# Altium schematic sheet stlink.SchDoc
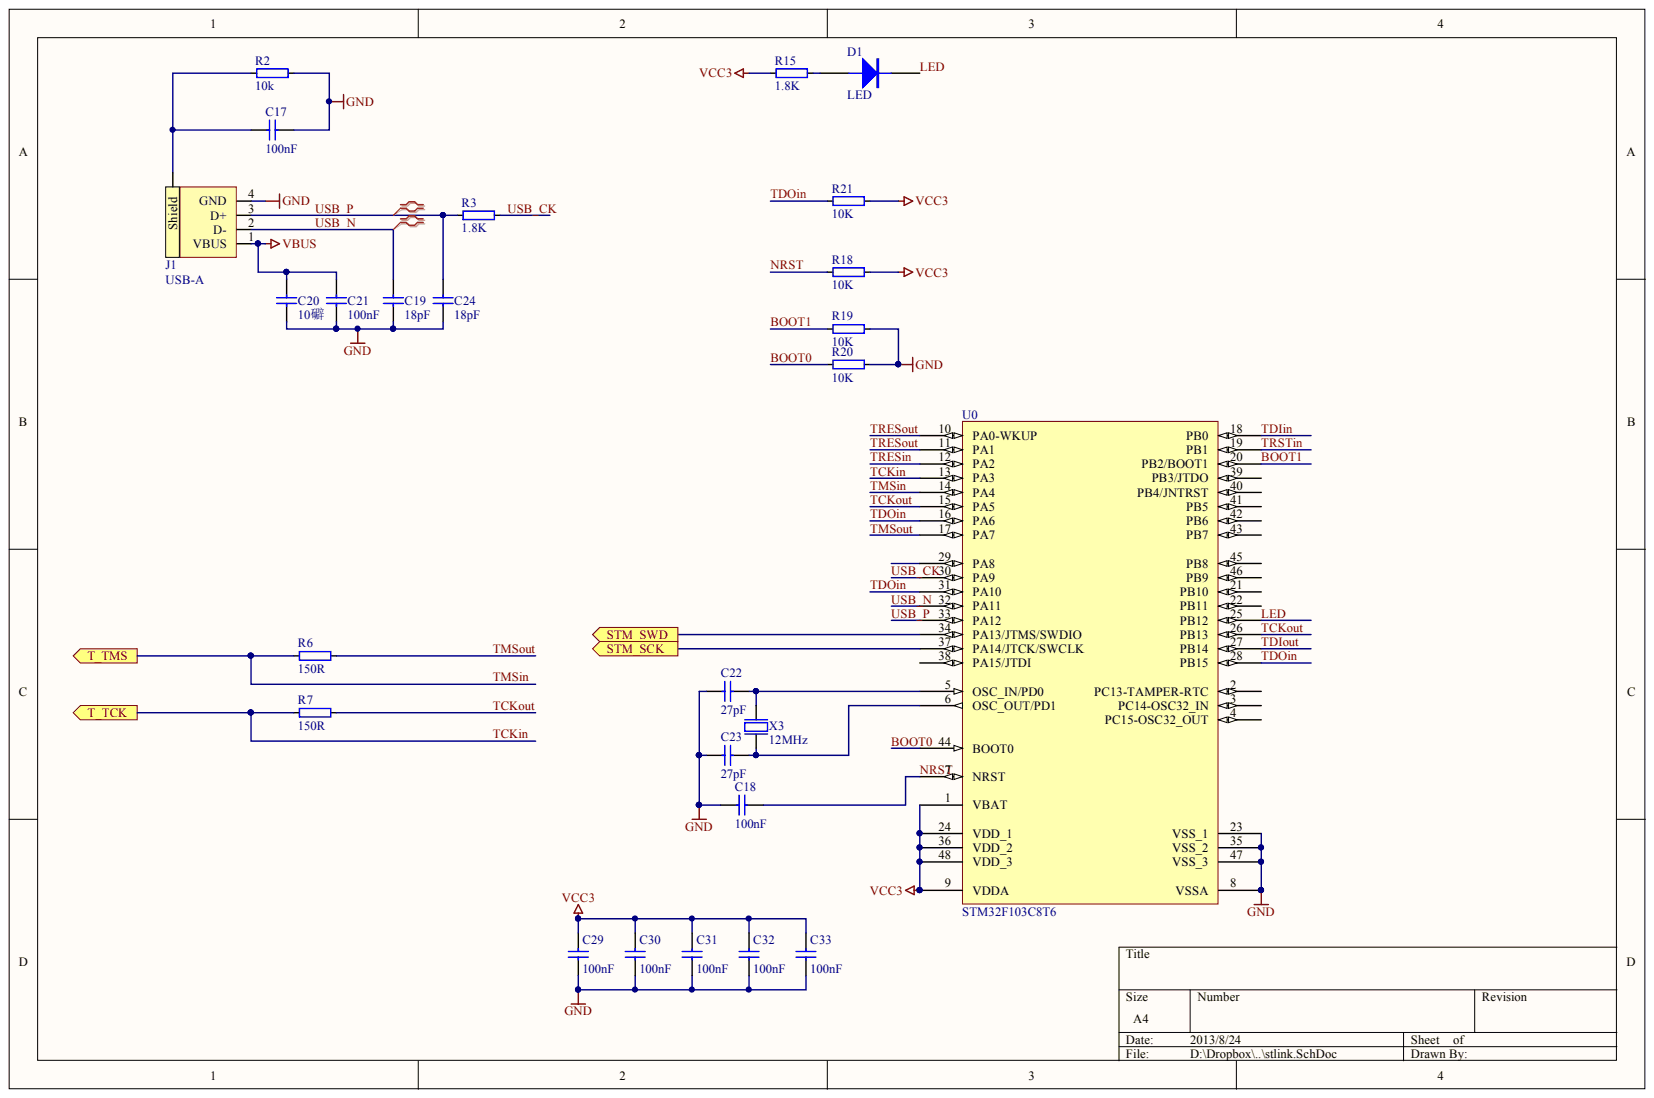
[diagram p0: stlink.SchDoc]

## Parts
C17  100nF  [0402]
C18  100nF  [0402]
C19  18pF  [0402]
C20  10礔  [0603]
C21  100nF  [0402]
C22  27pF  [0402]
C23  27pF  [0402]
C24  18pF  [0402]
C29  100nF  [0402]
C30  100nF  [0402]
C31  100nF  [0402]
C32  100nF  [0402]
C33  100nF  [0402]
D1  LED
J1  USB-A  [USB-PCB-A-SMD]
R2  10k  [0402]
R3  1.8K  [0402]
R6  150R  [0402]
R7  150R  [0402]
R15  1.8K  [0402]
R18  10K  [0402]
R19  10K  [0402]
R20  10K  [0402]
R21  10K  [0402]
U0  STM32F103C8T6
X3  12MHz  [BT-XTAL_3225]

## Ports
STM_SCK, STM_SWD, T_TCK, T_TMS

## Nets
GND: C17.1 C18.2 C19.1 C20.1 C21.1 C22.1 C23.1 C24.1 C29.1 C30.1 C31.1 C32.1 C33.1 J1.4(GND) R2.1 R19.2 R20.2 U0.8(VSSA) U0.23(VSS_1) U0.35(VSS_2) U0.47(VSS_3) X3.2 X3.4
VBUS: C20.2 C21.2 J1.1(VBUS)
VCC3: C29.2 C30.2 C31.2 C32.2 C33.2 R15.1 R18.2 R21.2 U0.1(VBAT) U0.9(VDDA) U0.24(VDD_1) U0.36(VDD_2) U0.48(VDD_3)
BOOT0: R20.1 U0.44(BOOT0)
BOOT1: R19.1 U0.20(PB2/BOOT1)
LED: D1.2 U0.25(PB12)
NRST: C18.1 R18.1 U0.7(NRST)
STM_SCK: U0.37(PA14/JTCK/SWCLK) ; port STM_SCK
STM_SWD: U0.34(PA13/JTMS/SWDIO) ; port STM_SWD
TCKIN: R7.2 U0.13(PA3) ; port T_TCK
TCKOUT: R7.1 U0.15(PA5) U0.26(PB13)
TDIIN: U0.18(PB0)
TDIOUT: U0.27(PB14)
TDOIN: R21.1 U0.16(PA6) U0.28(PB15) U0.31(PA10)
TMSIN: R6.2 U0.14(PA4) ; port T_TMS
TMSOUT: R6.1 U0.17(PA7)
TRESIN: U0.12(PA2)
TRESOUT: U0.10(PA0-WKUP) U0.11(PA1)
TRSTIN: U0.19(PB1)
USB_CK: R3.1 U0.30(PA9)
USB_N: C19.2 J1.2(D-) U0.32(PA11)
USB_P: C24.2 J1.3(D+) R3.2 U0.33(PA12)
NetC17_2: C17.2 J1.0(Shield) R2.2
NetC22_2: C22.2 U0.5(OSC_IN/PD0) X3.3
NetC23_2: C23.2 U0.6(OSC_OUT/PD1) X3.1
NetD1_1: D1.1 R15.2

Not connected: U0 2(PC13-TAMPER-RTC) 3(PC14-OSC32_IN) 4(PC15-OSC32_OUT) 21(PB10) 22(PB11) 29(PA8) 38(PA15/JTDI) 39(PB3/JTDO) 40(PB4/JNTRST) 41(PB5) 42(PB6) 43(PB7) 45(PB8) 46(PB9)

## Library data of the parts
C17 C18 C29-C33 = (no symbol name): Part Number: C0402-100n; Note: 16V; Artikkelnr Noca: 20039200; Manufacturer: *; Manufacturer Part Number: *; Datasheet: *; LatestRevisionDate: 2009-11-23; Pin Count: 2; Published: 2009-05-08; Value: 100nF; Supplier: *; Supplier part number: *; 2nd source Supplier: *; 2nd source part number: *; Publisher: Nordic Semiconductor
C19 C22-C24 = (no symbol name): Part Number: C0402-18p; Note: 50V; Artikkelnr Noca: 20029209; Manufacturer: *; Manufacturer Part Number: *; Datasheet: *; LatestRevisionDate: 2009-05-08; Pin Count: 2; Published: 2009-05-08; Supplier: *; Supplier part number: *; 2nd source Supplier: *; 2nd source part number: *; Publisher: Nordic Semiconductor
  C19 C24: Value: 18pF
  C22 C23: Value: 27pF
C20 = (no symbol name): Part Number: C0603-10; Note: 6.3V; Artikkelnr Noca: 20039252; Manufacturer: *; Manufacturer Part Number: *; Datasheet: *; LatestRevisionDate: 2011-01-17; Pin Count: 2; Published: 2011-01-17; Supplier: *; Supplier part number: *; 2nd source Supplier: *; 2nd source part number: *; Publisher: Nordic Semiconductor
C21 = (no symbol name): Part Number: C0402-12p; Note: 50V; Artikkelnr Noca: 20029159; Manufacturer: *; Manufacturer Part Number: *; Datasheet: *; LatestRevisionDate: 2011-05-11; Pin Count: 2; Published: 2009-05-08; Value: 100nF; Supplier: *; Supplier part number: *; 2nd source Supplier: *; 2nd source part number: *; Publisher: Nordic Semiconductor
J1 = (no symbol name): Part Number: Molex USB-A-SMT_w/shield; Manufacturer: Molex; Manufacturer Part Number: 48037-1000; 2nd source part number: 538-48037-1000; Note: MOLEX - 48037-1000; Artikkelnr Noca: 55079008; Value: USB-A; Datasheet: http://www.molex.com/pdm_docs/sd/480371000_sd.pdf; LatestRevisionDate: 2012-11-21; Published: 2012-11-21; Supplier: Digi-Key; Supplier part number: WM17118-ND; 2nd source Supplier: Mouser; Publisher: Nordic Semiconductor
R2 = (no symbol name): Part Number: R0402-0R; Note: Chip Res 5%; Artikkelnr Noca: 27049000; Value: 10k; Manufacturer: *; Manufacturer Part Number: *; Datasheet: *; LatestRevisionDate: 2009-11-23; Pin Count: 2; Published: 2009-05-08; Supplier: Farnell; Supplier part number: 146-9661; 2nd source Supplier: *; 2nd source part number: *; Publisher: Nordic Semiconductor
R3 = (no symbol name): Part Number: R0402-39R; Note: Chip Res 1%; Artikkelnr Noca: 27049039; Value: 1.8K; Manufacturer: *; Manufacturer Part Number: *; Datasheet: *; LatestRevisionDate: 2009-11-23; Pin Count: 2; Published: 2009-05-08; Supplier: Farnell; Supplier part number: 173-8831; 2nd source Supplier: *; 2nd source part number: *; Publisher: Nordic Semiconductor
R6 R7 = (no symbol name): Part Number: R0402-150; Note: Chip Res 1%; Artikkelnr Noca: 27049053; Manufacturer: *; Manufacturer Part Number: *; Datasheet: *; LatestRevisionDate: 2009-11-23; Pin Count: 2; Published: 2009-05-08; Value: 150R; Supplier: Farnell; Supplier part number: 173-8839; 2nd source Supplier: *; 2nd source part number: *; Publisher: Nordic Semiconductor
R15 R18-R21 = (no symbol name): Part Number: R0402-220; Note: Chip Res 1%; Artikkelnr Noca: 27049057; Manufacturer: *; Manufacturer Part Number: *; Datasheet: *; LatestRevisionDate: 2009-11-23; Pin Count: 2; Published: 2009-05-08; Supplier: Farnell; Supplier part number: 173-8841; 2nd source Supplier: *; 2nd source part number: *; Publisher: Nordic Semiconductor
  R15: Value: 1.8K
  R18-R21: Value: 10K
X3 = (no symbol name): Part Number: XTAL SMD 12MHz (3225); Manufacturer: TXC Corporation; Manufacturer Part Number: 7M-12.000MAAJ-T; 2nd source part number: 184-2056; Note: XTAL SMD 12.000MHz; Artikkelnr Noca: 22019166; Datasheet: http://www.txccrystal.com/images/pdf/7m.pdf; LatestRevisionDate: 2010-08-31; Pin Count: 4; Published: 2010-08-31; Value: 12MHz; Supplier: Digi-Key; Supplier part number: 887-1121-1-ND; 2nd source Supplier: Farnell; Publisher: Nordic Semiconductor
D1 U0 = (no symbol name)
  U0: Published: *; DatasheetVersion: *; PackageVersion: *; PackageReference: *; PackageDescription: *; ComponentLink1Description: *; ComponentLink1URL: *; ComponentLink2Description: *; ComponentLink2URL: *; Publisher: *
